# Altium schematic sheet FPGA_CLK_SUSPEND.SchDoc
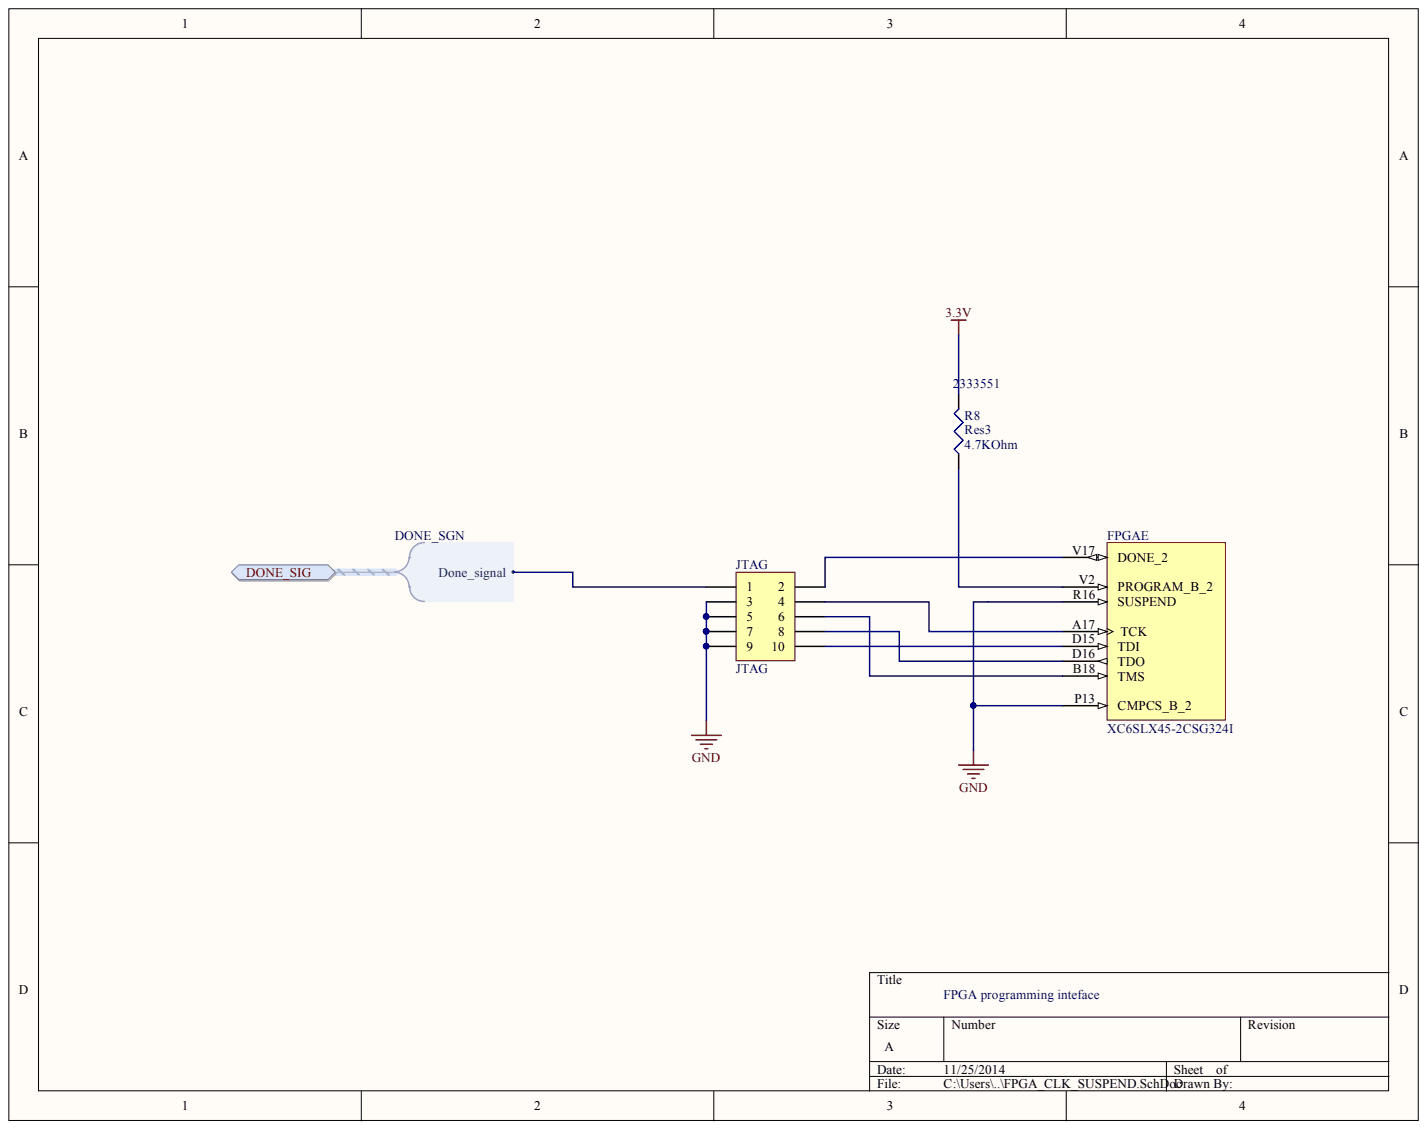
[diagram p0: FPGA_CLK_SUSPEND.SchDoc]

## Parts
FPGA  XC6SLX45-2CSG324I  [CSG324]  (part E)
JTAG  JTAG  [HDR2X5]
R8  Res3  value 4.7KOhm  Supplier Part Number 1: 2333551  [C1206]

## Ports
DONE_SIG, DONE_SIG.DONE_SIGNAL

## Nets
3.3V: R8.2
GND: FPGA.P13(CMPCS_B_2) FPGA.R16(SUSPEND) JTAG.3 JTAG.5 JTAG.7 JTAG.9
DONE_SIG: JTAG.1 ; port DONE_SIG
NetFPGA_A17: FPGA.A17(TCK) JTAG.4
NetFPGA_B18: FPGA.B18(TMS) JTAG.6
NetFPGA_D15: FPGA.D15(TDI) JTAG.10
NetFPGA_D16: FPGA.D16(TDO) JTAG.8
NetFPGA_V2: FPGA.V2(PROGRAM_B_2) R8.1
NetFPGA_V17: FPGA.V17(DONE_2) JTAG.2

## Library data of the parts
FPGA = CMP-0067-00402-1: Spartan-6 LX 1.2V FPGA, 218 User I/Os, 324-Ball Chip-Scale BGA (0.8mm Pitch), Speed Grade 2, Industrial Grade, Pb-Free; Code_JEDEC: MO-275-KKAC-1; Component Kind: Standard; DatasheetVersion: ver1.6, Nov-2010; Library Name: Altium Content Vault; PackageDescription: 324-Ball Chip-Scale BGA (CSG324) Package, 0.8 mm Pitch; PackageReference: CSG324; PackageVersion: ver1.1, Nov-2009
JTAG = Header 5X2: Header, 5-Pin, Dual row; Component Kind: Standard; LatestRevisionDate: 17-Jul-2002; LatestRevisionNote: Re-released for DXP Platform.; Library Name: Miscellaneous Connectors.IntLib; Signal Integrity: Connector; Publisher: Altium Limited
R8 = Res3: Resistor; Manufacturer: BOURNS; Manufacturer Part Number: CR1206-FX-4701ELF; Power Rating: 250; Product Range: BOURNS - CR Series; Resistance: 4.7; Resistance Tolerance: ± 1%; Resistor Case Style: 1206 [3216 Metric]; Resistor Element Material: Thick Film; SVHC: No SVHC (16-Jun-2014; Temperature Coefficient: ± 100; Voltage Rating: 200; Component Kind: Standard; ComponentLink1Description: http://www.farnell.com/datasheets/691949.pdf; ComponentLink1URL: http://www.farnell.com/datasheets/691949.pdf; LatestRevisionDate: 29-May-2009; LatestRevisionNote: IPC-7351 Footprint Added.; Library Name: Miscellaneous Devices.IntLib; MSL: -; PackageDescription: Chip Resistor; PackageReference: J1-0603; Packaging: Cut Tape; Pricing 1: 1=0.453, 10=0.34, 50=0.278, 100=0.216, 500=0.1607, 1000=0.1339 (EUR); Published: 8-Jun-2000; RoHS: YES; Signal Integrity: Res; Simulation: RESISTOR; Stock 1: 12041; Supplier 1: Farnell; Publisher: Altium Limited
